# Altium schematic sheet TempRFOut.SchDoc
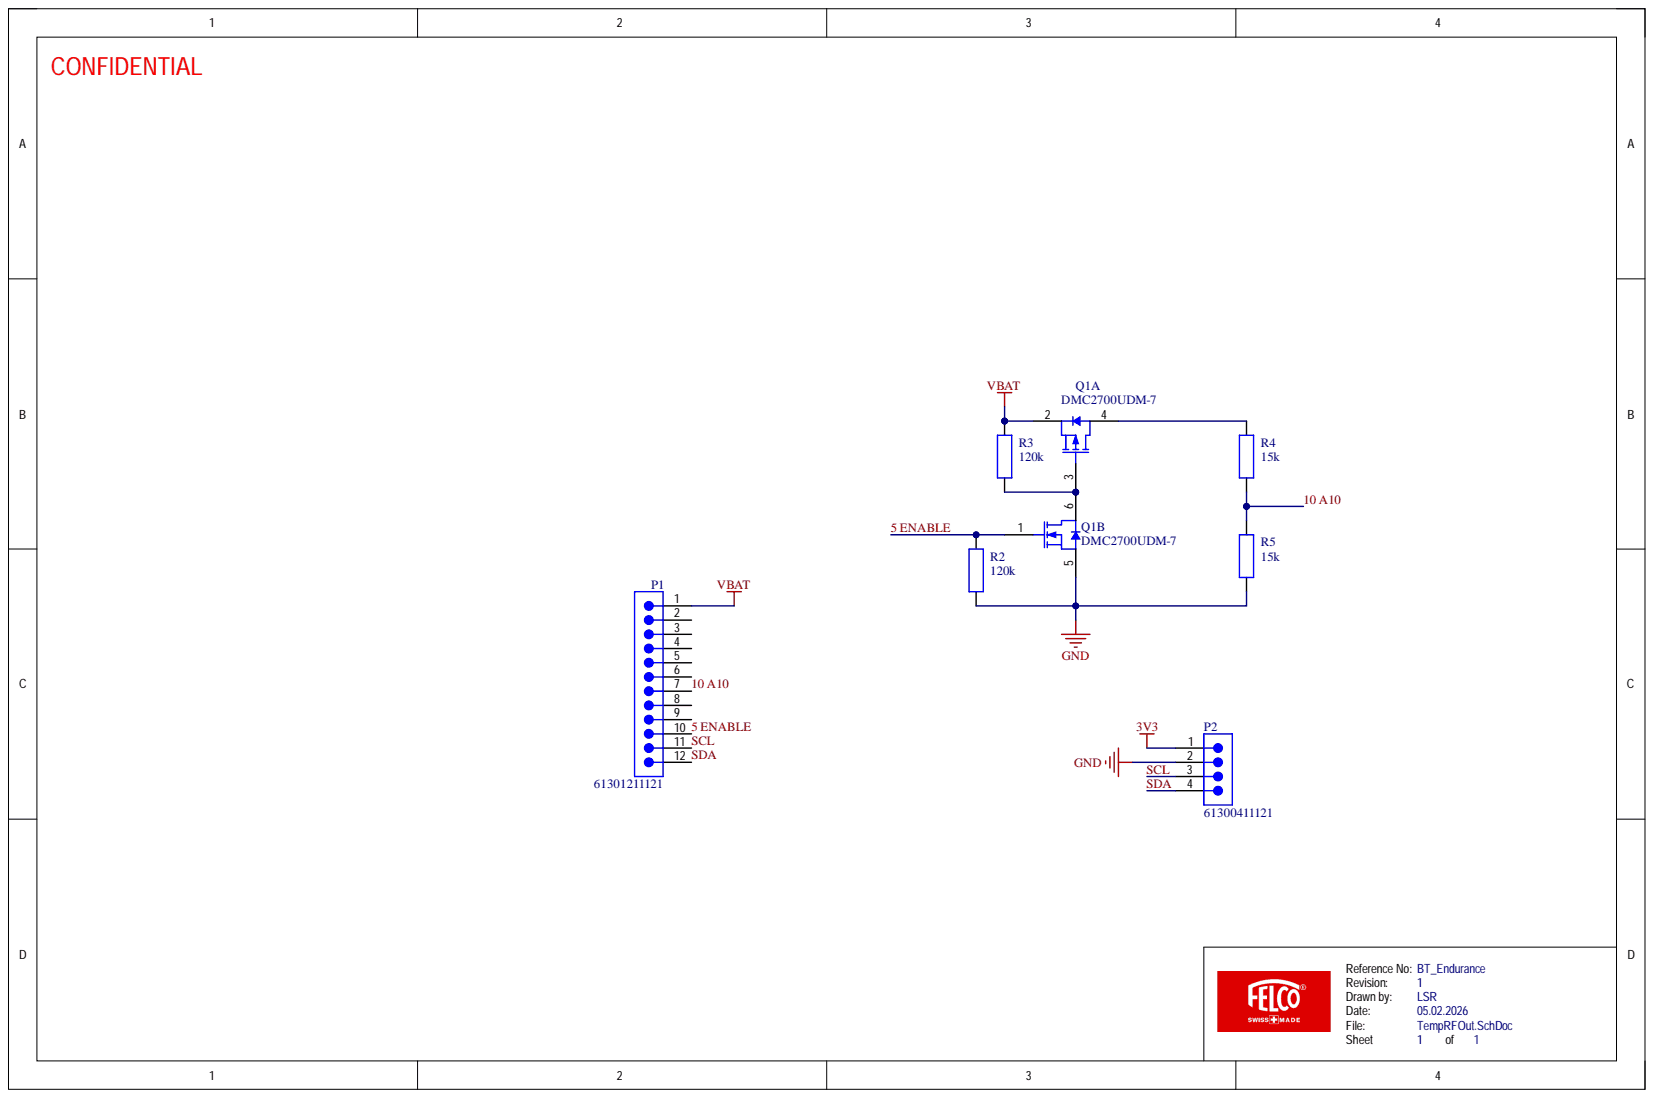
[diagram p0: TempRFOut.SchDoc]

## Parts
P1  61301211121  [61301211121]
P2  61300411121  [61300411121]
Q1  DMC2700UDM-7  [FAIR-SOT23-6_V]  (parts A,B)
R2  120k  [0603]
R3  120k  [0603]
R4  15k  [0603]
R5  15k  [0603]

## Nets
3V3: P2.1
GND: P2.2 Q1.5 R2.1 R5.1
VBAT: P1.1 Q1.2 R3.2
5 ENABLE: P1.10 Q1.1 R2.2
10 A10: P1.7 R4.1 R5.2
SCL: P1.11 P2.3
SDA: P1.12 P2.4
NetQ1_3: Q1.3 Q1.6 R3.1
NetQ1_4: Q1.4 R4.2

Not connected: P1 2 3 4 5 6 8 9

## Library data of the parts
P1 = CMP-1502-01086-4: THT Vertical Pin Header WR-PHD, Pitch 2.54 mm, Single Row, 12 pins; Connector Type: Male Pin; Contact Resistance: 20mΩ; Current Rating: 3A; Gender: Male; Number of Contacts: 12; Operating Supply Voltage: 250V; Pitch: 2.54mm; Color: Black; Component Kind: Standard; Contact Material: Copper; Contact Mating Length: 5.9944mm; Contact Plating: Gold; Lead Pitch: 2.54mm; Library Name: Altium Content Vault; Mount: Through Hole; Number of Pins: 12; Number of Positions: 12; Number of Rows: 1; Orientation: Vertical; Packaging: Bulk; RoHS: Compliant; Termination: Solder
P2 = CMP-1502-01064-4: THT Vertical Pin Header WR-PHD, Pitch 2.54 mm, Single Row, 4 pins; Connector Type: Male Pin; Contact Resistance: 20mΩ; Current Rating: 3A; Gender: Male; Number of Contacts: 4; Operating Supply Voltage: 250V; Pitch: 2.54mm; Color: Black; Component Kind: Standard; Contact Material: Gold; Contact Mating Length: 5.9944mm; Contact Plating: Gold; Height: 8.89mm; Lead Free: Lead Free; Lead Pitch: 2.54mm; Library Name: Altium Content Vault; Max Operating Temperature: 105°C; Min Operating Temperature: -40°C; Mount: Through Hole; Number of Pins: 4; Number of Positions: 4; Number of Rows: 1; Orientation: Vertical; Packaging: Bulk; RoHS: Compliant; Termination: Solder; Voltage Rating: 250V
Q1 = CMP-2000-04939-1: Dual N & P-Channel 2.5 V Specified PowerTrench(TM) MOSFET, -55 to 150 degC, 6-Pin SOT-23, RoHS, Tape and Reel; Manufacturer: Fairchild; Channel Mode: Enhancement; Maximum Continuous Drain Current: 2.7@NChannel; Maximum Drain Source Voltage: 20V; Maximum Gate Source Voltage: ±8V; Package Version: Rev. 5, 05/2015; Rad Hard: No; RDS-on: 80@4.5V@NChannel; Typical Fall Time: 3@NChannel; Typical Rise Time: 9@NChannel; Typical Turn-Off Delay Time: 12@NChannel; Typical Turn-On Delay Time: 5@NChannel; Category: MOSFET; Channel Type: N; Component Kind: Standard; Datasheet URL: https://www.fairchildsemi.com/datasheets/FD/FDC6327C.pdf; Datasheet Version: Rev. E, 07/2000; Library Name: Altium Content Vault; Manufacturer URL: https://www.fairchildsemi.com/; Mounting: SurfaceMount; Operating Temperature: -55to150degC; Package: 6SuperSOT; Package Description: 6-Pin Small Outline Transistor, Body 2.9 x 1.6 mm, Pitch 0.95 mm; Package Reference: SOT23-6; Package URL: https://www.fairchildsemi.com/package-drawings/MA/MA06A.pdf; Packaging: Tape&Reel
R2-R5 = R: Resistor; Component Kind: Standard; ComponentLink1Description: http://www.yageo.com/documents/recent/PYu-RC_Group_51_RoHS_L_7.pdf; ComponentLink1URL: http://www.yageo.com/documents/recent/PYu-RC_Group_51_RoHS_L_7.pdf; Library Name: MyProtel_1.SchLib
